# Altium schematic sheet Power Distribution - Front Lights.SchDoc
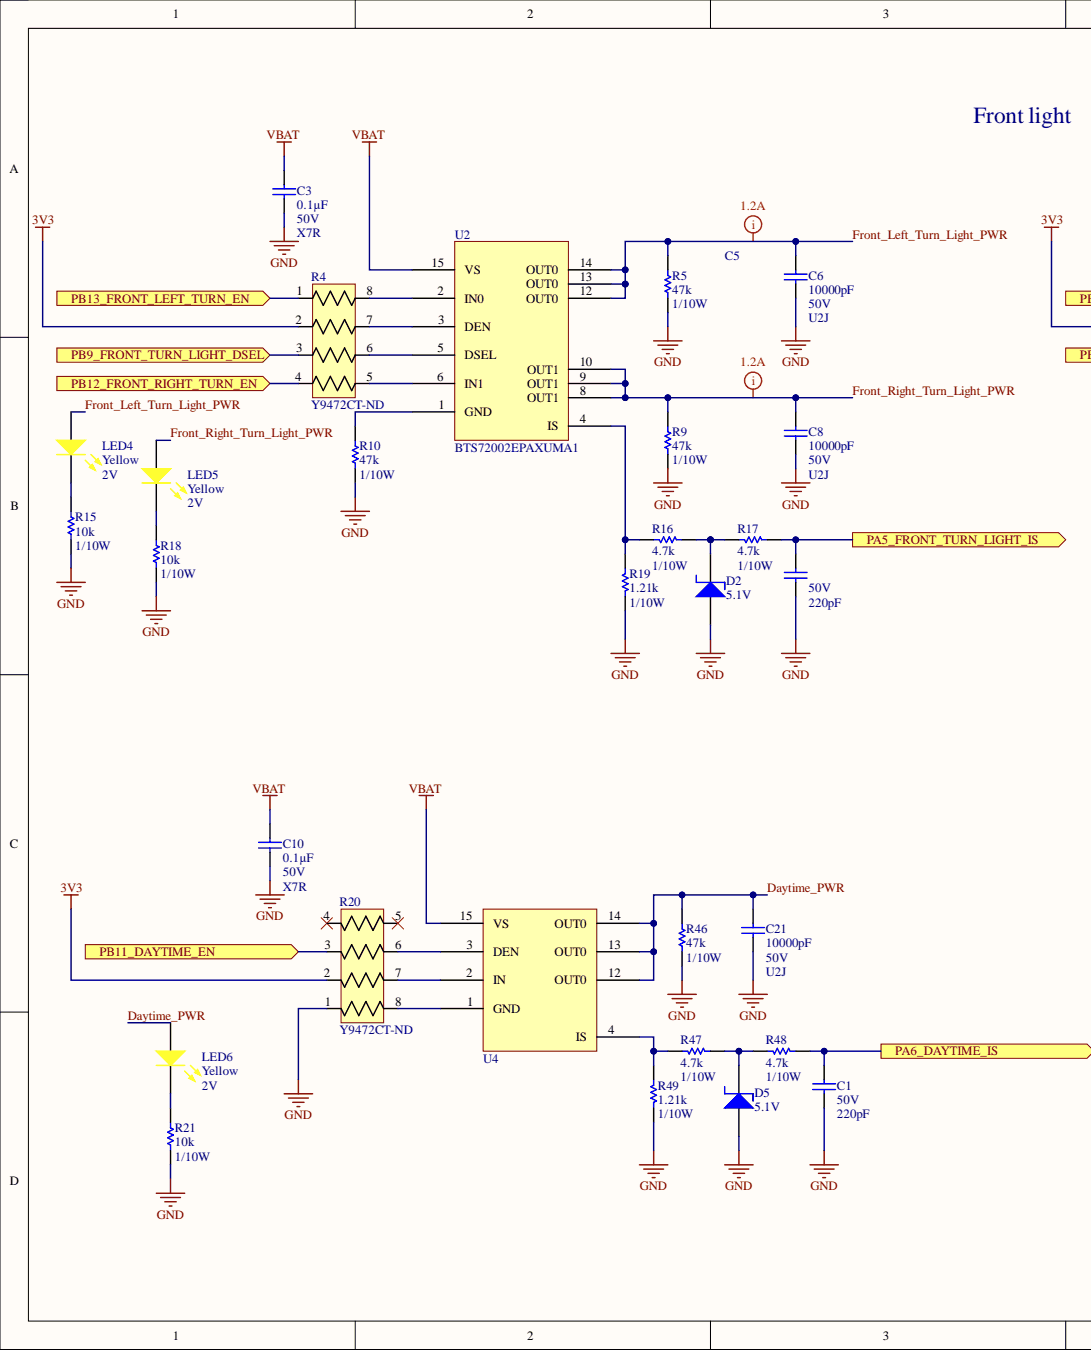
[diagram p0: Power Distribution - Front Lights.SchDoc, left]
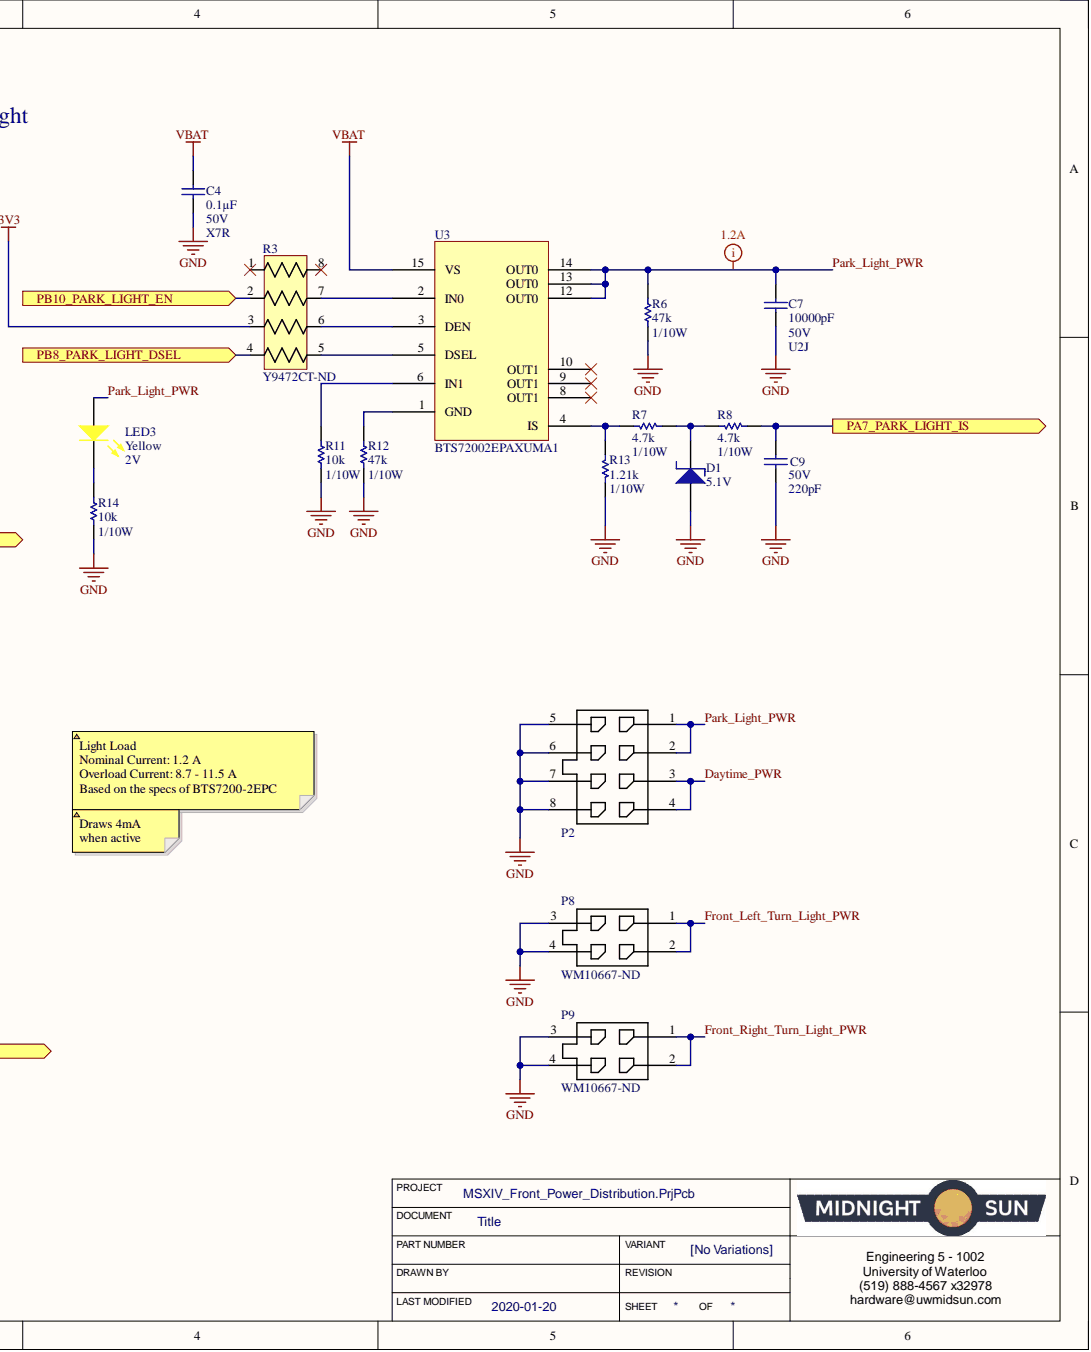
[diagram p1: Power Distribution - Front Lights.SchDoc, right]

## Parts
C1  Capacitance: 220pF  Voltage Rating: 50V  [CAP, 0603]  (hidden comment: CAP CER 220PF 50V C0G/NP0 0603)
C3  Capacitance: 0.1µF  Temperature Coefficient: X7R  Voltage: 50V  [CAP, 0603]  (hidden comment: CAP CER 0.1UF 50V 10% X7R 0603)
C4  Capacitance: 0.1µF  Temperature Coefficient: X7R  Voltage: 50V  [CAP, 0603]  (hidden comment: CAP CER 0.1UF 50V 10% X7R 0603)
C5  Capacitance: 220pF  Voltage Rating: 50V  [CAP, 0603]  (hidden comment: CAP CER 220PF 50V C0G/NP0 0603)
C6  Capacitance: 10000pF  Temperature Coefficient: U2J  Voltage: 50V  [CAP, 0603]  (hidden comment: CAP CER 10nF 50V 5% X7R 0603)
C7  Capacitance: 10000pF  Temperature Coefficient: U2J  Voltage: 50V  [CAP, 0603]  (hidden comment: CAP CER 10nF 50V 5% X7R 0603)
C8  Capacitance: 10000pF  Temperature Coefficient: U2J  Voltage: 50V  [CAP, 0603]  (hidden comment: CAP CER 10nF 50V 5% X7R 0603)
C9  Capacitance: 220pF  Voltage Rating: 50V  [CAP, 0603]  (hidden comment: CAP CER 220PF 50V C0G/NP0 0603)
C10  Capacitance: 0.1µF  Temperature Coefficient: X7R  Voltage: 50V  [CAP, 0603]  (hidden comment: CAP CER 0.1UF 50V 10% X7R 0603)
C21  Capacitance: 10000pF  Temperature Coefficient: U2J  Voltage: 50V  [CAP, 0603]  (hidden comment: CAP CER 10nF 50V 5% X7R 0603)
D1  Voltage - Zener (Nom) (Vz): 5.1V  [DO-214AA Diode]  (hidden comment: DIODE ZENER 5.1V 500MW SOD123)
D2  Voltage - Zener (Nom) (Vz): 5.1V  [DO-214AA Diode]  (hidden comment: DIODE ZENER 5.1V 500MW SOD123)
D5  Voltage - Zener (Nom) (Vz): 5.1V  [DO-214AA Diode]  (hidden comment: DIODE ZENER 5.1V 500MW SOD123)
LED3  Color: Yellow  Voltage - Forward (Vf) (Typ): 2V  [LED_0603]  (hidden comment: LED YELLOW CLEAR 2.1V 0603)
LED4  Color: Yellow  Voltage - Forward (Vf) (Typ): 2V  [LED_0603]  (hidden comment: LED YELLOW CLEAR 2.1V 0603)
LED5  Color: Yellow  Voltage - Forward (Vf) (Typ): 2V  [LED_0603]  (hidden comment: LED YELLOW CLEAR 2.1V 0603)
LED6  Color: Yellow  Voltage - Forward (Vf) (Typ): 2V  [LED_0603]  (hidden comment: LED YELLOW CLEAR 2.1V 0603)
P2  CONN 8POS MICRO-FIT 3mm  [CONN, 8POS MICRO-FIT]  (value not drawn)
P8  Supplier Part Number 1: WM10667-ND  [CONN 4POS MICROFIT (2x2)]  (hidden comment: CONN 4POS MICRO-FIT 3mm)
P9  Supplier Part Number 1: WM10667-ND  [CONN 4POS MICROFIT (2x2)]  (hidden comment: CONN 4POS MICRO-FIT 3mm)
R3  Supplier Part Number 1: Y9472CT-ND  [RES ARRAY 4RES 1206]  (hidden comment: RES ARRAY 4 RES 4.7K OHM 1206)
R4  Supplier Part Number 1: Y9472CT-ND  [RES ARRAY 4RES 1206]  (hidden comment: RES ARRAY 4 RES 4.7K OHM 1206)
R5  Power Rating: 1/10W  Resistance: 47k  [RES, 0603]  (hidden comment: RES 47K OHM 1% 1/10W 0603)
R6  Power Rating: 1/10W  Resistance: 47k  [RES, 0603]  (hidden comment: RES 47K OHM 1% 1/10W 0603)
R7  Resistance (Ohms): 4.7k  [RES, 0603]  (hidden comment: RES 4.7K OHM 1% 1/10W 0603)
R8  Resistance (Ohms): 4.7k  [RES, 0603]  (hidden comment: RES 4.7K OHM 1% 1/10W 0603)
R9  Power Rating: 1/10W  Resistance: 47k  [RES, 0603]  (hidden comment: RES 47K OHM 1% 1/10W 0603)
R10  Power Rating: 1/10W  Resistance: 47k  [RES, 0603]  (hidden comment: RES 47K OHM 1% 1/10W 0603)
R11  Power: 1/10W  Resistance: 10k  [RES, 0603]  (hidden comment: RES 10K OHM 1% 1/10W 0603)
R12  Power Rating: 1/10W  Resistance: 47k  [RES, 0603]  (hidden comment: RES 47K OHM 1% 1/10W 0603)
R13  Power: 1/10W  Resistance: 1.21k  [RES, 0603]  (hidden comment: RES 1.21K OHM 1% 1/10W 0603)
R14  Power: 1/10W  Resistance: 10k  [RES, 0603]  (hidden comment: RES 10K OHM 1% 1/10W 0603)
R15  Power: 1/10W  Resistance: 10k  [RES, 0603]  (hidden comment: RES 10K OHM 1% 1/10W 0603)
R16  Resistance (Ohms): 4.7k  [RES, 0603]  (hidden comment: RES 4.7K OHM 1% 1/10W 0603)
R17  Resistance (Ohms): 4.7k  [RES, 0603]  (hidden comment: RES 4.7K OHM 1% 1/10W 0603)
R18  Power: 1/10W  Resistance: 10k  [RES, 0603]  (hidden comment: RES 10K OHM 1% 1/10W 0603)
R19  Power: 1/10W  Resistance: 1.21k  [RES, 0603]  (hidden comment: RES 1.21K OHM 1% 1/10W 0603)
R20  Supplier Part Number 1: Y9472CT-ND  [RES ARRAY 4RES 1206]  (hidden comment: RES ARRAY 4 RES 4.7K OHM 1206)
R21  Power: 1/10W  Resistance: 10k  [RES, 0603]  (hidden comment: RES 10K OHM 1% 1/10W 0603)
R46  Power Rating: 1/10W  Resistance: 47k  [RES, 0603]  (hidden comment: RES 47K OHM 1% 1/10W 0603)
R47  Resistance (Ohms): 4.7k  [RES, 0603]  (hidden comment: RES 4.7K OHM 1% 1/10W 0603)
R48  Resistance (Ohms): 4.7k  [RES, 0603]  (hidden comment: RES 4.7K OHM 1% 1/10W 0603)
R49  Power: 1/10W  Resistance: 1.21k  [RES, 0603]  (hidden comment: RES 1.21K OHM 1% 1/10W 0603)
U2  Manufacturer Part Number: BTS72002EPAXUMA1  [IC LOAD SWITCH PG-TSDSO-14-22]  (hidden comment: IC LOAD SWITCH BTS7200-2EPA PG-TSDSO-14_1)
U3  Manufacturer Part Number: BTS72002EPAXUMA1  [IC LOAD SWITCH PG-TSDSO-14-22]  (hidden comment: IC LOAD SWITCH BTS7200-2EPA PG-TSDSO-14_1)
U4  IC LOAD SWITCH BTS70401EPA PG-TSDSO-14-22  [IC LOAD SWITCH PG-TSDSO-14-22]  (value not drawn)

## Ports
PA5_FRONT_TURN_LIGHT_IS, PA6_DAYTIME_IS, PA7_PARK_LIGHT_IS, PB8_PARK_LIGHT_DSEL, PB9_FRONT_TURN_LIGHT_DSEL, PB10_PARK_LIGHT_EN, PB11_DAYTIME_EN, PB12_FRONT_RIGHT_TURN_EN, PB13_FRONT_LEFT_TURN_EN

## Nets
3V3: R3.3 R4.2 R20.2
GND: C1.2 C3.2 C4.2 C5.2 C6.2 C7.2 C8.2 C9.2 C10.2 C21.2 D1.1 D2.1 D5.1 P2.5 P2.6 P2.7 P2.8 P8.3 P8.4 P9.3 P9.4 R5.2 R6.2 R9.2 R10.2 R11.2 R12.2 R13.2 R14.2 R15.2 R18.2 R19.2 R20.1 R21.2 R46.2 R49.2
VBAT: C3.1 C4.1 C10.1 U2.15(VS) U3.15(VS) U4.15(VS)
Daytime_PWR: C21.1 LED6.1 P2.3 P2.4 R46.1 U4.12(OUT0) U4.13(OUT0) U4.14(OUT0)
Front_Left_Turn_Light_PWR: C6.1 LED4.1 P8.1 P8.2 R5.1 U2.12(OUT0) U2.13(OUT0) U2.14(OUT0)
Front_Right_Turn_Light_PWR: C8.1 LED5.1 P9.1 P9.2 R9.1 U2.8(OUT1) U2.9(OUT1) U2.10(OUT1)
PA5_FRONT_TURN_LIGHT_IS: C5.1 R17.1 ; port PA5_FRONT_TURN_LIGHT_IS
PA6_DAYTIME_IS: C1.1 R48.1 ; port PA6_DAYTIME_IS
PA7_PARK_LIGHT_IS: C9.1 R8.1 ; port PA7_PARK_LIGHT_IS
Park_Light_PWR: C7.1 LED3.1 P2.1 P2.2 R6.1 U3.12(OUT0) U3.13(OUT0) U3.14(OUT0)
PB8_PARK_LIGHT_DSEL: R3.4 ; port PB8_PARK_LIGHT_DSEL
PB9_FRONT_TURN_LIGHT_DSEL: R4.3 ; port PB9_FRONT_TURN_LIGHT_DSEL
PB10_PARK_LIGHT_EN: R3.2 ; port PB10_PARK_LIGHT_EN
PB11_DAYTIME_EN: R20.3 ; port PB11_DAYTIME_EN
PB12_FRONT_RIGHT_TURN_EN: R4.4 ; port PB12_FRONT_RIGHT_TURN_EN
PB13_FRONT_LEFT_TURN_EN: R4.1 ; port PB13_FRONT_LEFT_TURN_EN
NetD1_2: D1.2 R7.1 R8.2
NetD2_2: D2.2 R16.1 R17.2
NetD5_2: D5.2 R47.1 R48.2
NetLED3_2: LED3.2 R14.1
NetLED4_2: LED4.2 R15.1
NetLED5_2: LED5.2 R18.1
NetLED6_2: LED6.2 R21.1
NetR3_5: R3.5 U3.5(DSEL)
NetR3_6: R3.6 U3.3(DEN)
NetR3_7: R3.7 U3.2(IN0)
NetR4_5: R4.5 U2.6(IN1)
NetR4_6: R4.6 U2.5(DSEL)
NetR4_7: R4.7 U2.3(DEN)
NetR4_8: R4.8 U2.2(IN0)
NetR7_2: R7.2 R13.1 U3.4(IS)
NetR10_1: R10.1 U2.1(GND)
NetR11_1: R11.1 U3.6(IN1)
NetR12_1: R12.1 U3.1(GND)
NetR16_2: R16.2 R19.1 U2.4(IS)
NetR20_6: R20.6 U4.3(DEN)
NetR20_7: R20.7 U4.2(IN)
NetR20_8: R20.8 U4.1(GND)
NetR47_2: R47.2 R49.1 U4.4(IS)

Not connected: R3 1 8; R20 4 5; U3 8(OUT1) 9(OUT1) 10(OUT1)

## Library data of the parts
C1 C5 C9 = CAP CER 220PF 50V C0G/NP0 0603: 220pF ±5% 50V Ceramic Capacitor C0G, NP0 0603 (1608 Metric); Manufacturer: KEMET; Manufacturer Part Number: C0603C221J5GACAUTO; Dissipation Factor: 0.1%; Case Code (Imperial): 0603; Case Code (Metric): 1608; Case/Package: 0603; Component Kind: Standard; ComponentLink1Description: https://datasheet.ciiva.com/18192/getdatasheetpartid-416741-18192869.pdf?src-supplier=Verical; ComponentLink1URL: https://datasheet.ciiva.com/18192/getdatasheetpartid-416741-18192869.pdf?src-supplier=Verical; ComponentLink2Description: https://datasheet.ciiva.com/22694/1633156-22694015.pdf?src-supplier=Element14; ComponentLink2URL: https://datasheet.ciiva.com/22694/1633156-22694015.pdf?src-supplier=Element14; Contact Plating: Tin; Depth: 0.8mm; Dielectric: C0G; Dielectric Material: Ceramic; Height: 0.8mm; Lead Free: Lead Free; Length: 1.6mm; Max Operating Temperature: 125°C; Min Operating Temperature: -55°C; Mount: Surface Mount; Package Quantity: 1; Packaging: Tape and Reel; Pricing 1: 1=0.14000, 10=0.09700, 100=0.04550, 500=0.03188, 1000=0.02640 (USD); Radiation Hardening: No; Ratings: AEC-Q200; REACH SVHC: No SVHC; RoHS: Yes; Stock 1: 35170; Supplier 1: Digi-Key; Supplier Part Number 1: 399-6868-1-ND; Termination: SMD/SMT; Thickness: 0.034inch; Tolerance: 5%; Voltage Rating (DC): 50V; Width: 0.031inch; Library Name: Schematic Diagrams.SchLib
C3 C4 C10 = CAP CER 0.1UF 50V 10% X7R 0603: 0.10µF ±10% 50V Ceramic Capacitor X7R 0603 (1608 Metric); Manufacturer 1: AVX Corporation; Manufacturer Part Number 1: 06035C104KAT2A; Component Kind: Standard; ComponentLink1Description: http://datasheets.avx.com/X7RDielectric.pdf; ComponentLink1URL: http://datasheets.avx.com/X7RDielectric.pdf; Height - Seated (Max): -; Lead Spacing: -; Lead Style: -; Mounting Type: Surface Mount, MLCC; Operating Temperature: -55°C ~ 125°C; Package / Case: 0603 (1608 Metric); Packaging: Cut Tape (CT); Part Status: Active; Ratings: -; Size / Dimension: 0.063" L x 0.032" W (1.60mm x 0.81mm); Supplier 1: Digi-Key; Supplier Part Number 1: 478-5052-1-ND; Thickness (Max): 0.035" (0.90mm); Tolerance: ±10%; Library Name: Schematic Diagrams.SchLib
C6-C8 C21 = CAP CER 10nF 50V 5% X7R 0603: 10000pF ±5% 50V Ceramic Capacitor U2J 0603 (1608 Metric); Manufacturer 1: KEMET; Manufacturer Part Number 1: C0603C103J5JAC7867; Component Kind: Standard; ComponentLink1Description: http://www.kemet.com/docfinder?Partnumber=C0603C103J5JAC7867; ComponentLink1URL: http://www.kemet.com/docfinder?Partnumber=C0603C103J5JAC7867; Failure Rate: -; Height - Seated (Max): -; Lead Spacing: -; Lead Style: -; Mounting Type: Surface Mount, MLCC; Operating Temperature: -55°C ~ 125°C; Package / Case: 0603 (1608 Metric); Packaging: Cut Tape (CT); Part Status: Active; Ratings: -; Size / Dimension: 0.063" L x 0.032" W (1.60mm x 0.80mm); Supplier 1: Digi-Key; Supplier Part Number 1: 399-13384-1-ND; Thickness (Max): 0.034" (0.87mm); Tolerance: ±5%; Library Name: Schematic Diagrams.SchLib
LED3-LED6 = LED YELLOW CLEAR 2.1V 0603: Yellow 590nm LED Indication - Discrete 2V 0603 (1608 Metric); Manufacturer: Wurth Electronics Inc.; Manufacturer Part Number: 150060YS75000; Current - Test: 20mA; Lens Color: Colorless; Lens Style/Size: Rectangle with Flat Top, 1.10mm x 0.70mm; Millicandela Rating: 120mcd; Viewing Angle: 140°; Wavelength - Peak: 595nm; Category: Optoelectronics; Component Kind: Standard; ComponentLink1Description: http://katalog.we-online.de/led/datasheet/150060YS75000.pdf; ComponentLink1URL: http://katalog.we-online.de/led/datasheet/150060YS75000.pdf; Features: -; Height (Max): 0.80mm; Lens Transparency: Clear; Mounting Type: Surface Mount; Package / Case: 0603 (1608 Metric); Packaging: Cut Tape (CT); Part Status: Active; Pricing 1: 1=0.21, 100=0.1617, 500=0.13964 (CAD); RoHS: RoHS Compliant; Size / Dimension: 1.60mm L x 0.80mm W; Stock 1: 10523; Supplier 1: Digi-Key; Supplier Device Package: 0603; Supplier Part Number 1: 732-4981-1-ND; Wavelength - Dominant: 590nm; Library Name: Schematic Diagrams.SchLib
P2 = CONN 8POS MICRO-FIT 3mm: Connector Header Through Hole 6 position 0.118" (3.00mm); Manufacturer: Molex, LLC; Manufacturer Part Number: 0430450827; Contact Length - Post: 0.125" (3.18mm); Material Flammability Rating: UL94 V-0; Number of Positions: 6; Number of Positions Loaded: All; Shrouding: Shrouded - 4 Wall; Style: Board to Cable/Wire; Applications: General Purpose, Medical, Military, Telecommunications; Category: Connectors, Interconnects; Component Kind: Standard; ComponentLink1Description: https://www.molex.com/pdm_docs/ps/PS-43045.pdf; ComponentLink1URL: https://www.molex.com/pdm_docs/ps/PS-43045.pdf; ComponentLink2Description: https://www.molex.com/webdocs/datasheets/pdf/en-us/0430450827_PCB_HEADERS.pdf; ComponentLink2URL: https://www.molex.com/webdocs/datasheets/pdf/en-us/0430450827_PCB_HEADERS.pdf; Connector Type: Header; Contact Finish - Mating: Tin; Contact Finish - Post: Tin; Contact Finish Thickness - Mating: 60.0µin (1.52µm); Contact Finish Thickness - Post: -; Contact Length - Mating: -; Contact Material: Brass; Contact Shape: Square; Contact Type: Male Pin; Current Rating: -; Fastening Type: Locking Ramp; Features: Board Guide; Ingress Protection: -; Insulation Color: Black; Insulation Height: 0.390" (9.91mm); Insulation Material: Liquid Crystal Polymer (LCP), Glass Filled; Mated Stacking Heights: -; Mounting Type: Through Hole; Number of Rows: 2; Operating Temperature: -; Overall Contact Length: -; Packaging: Tray; Part Status: Active; Pitch - Mating: 0.118" (3.00mm); Pricing 1: 1=2.69, 10=2.445, 25=2.2964, 50=2.1964, 100=2.0965, 250=1.8968, 500=1.74704, 1440=1.49746, 2880=1.39763 (CAD); RoHS: RoHS Compliant; Row Spacing - Mating: 0.118" (3.00mm); Stock 1: 5553; Supplier 1: Digi-Key; Supplier Part Number 1: WM10684-ND; Termination: Solder; Voltage Rating: -; Library Name: Schematic Diagrams.SchLib
P8 P9 = CONN 4POS MICRO-FIT 3mm: Micro-Fit 3.0 Vertical Header, 3.00mm Pitch, Dual Row, 4 Circuits, with PCB Polarizing Peg, Tin, Glow-Wire Capable, Black; Manufacturer: Molex, LLC; Manufacturer Part Number: 430450427; Contact Length - Post: 0.125" (3.18mm); Contact Resistance: 10mR; Material Flammability Rating: UL94 V-0; Number of Contacts: 4; Number of Ports: 1; Number of Positions: 6; Number of Positions Loaded: All; Pitch - Mating: 0.118" (3.00mm); Row Spacing: 0.118inch; Shrouding: Shrouded - 4 Wall; Style: Board to Cable/Wire; Applications: General Purpose, Medical, Military, Telecommunications; Category: Connectors, Interconnects; Color: Black; Component Kind: Standard; ComponentLink4Description: http://www.molex.com/webdocs/datasheets/pdf/en-us/0430450427_PCB_HEADERS.pdf; ComponentLink4URL: http://www.molex.com/webdocs/datasheets/pdf/en-us/0430450427_PCB_HEADERS.pdf; Connector Type: Header; Contact Finish - Mating: Tin; Contact Finish - Post: Tin; Contact Finish Thickness - Mating: 60.0µin (1.52µm); Contact Finish Thickness - Post: -; Contact Length - Mating: -; Contact Material: Brass; Contact Plating: Tin; Contact Shape: Square; Contact Type: Male Pin; Current Rating: -; Depth: 8.77mm; Fastening Type: Locking Ramp; Features: Board Guide; Housing Color: Black; Housing Material: Polymer; Ingress Protection: -; Insulation Color: Black; Insulation Height: 0.390" (9.91mm); Insulation Material: Liquid Crystal Polymer (LCP), Glass Filled; Length: 10.15mm; Mated Stacking Heights: -; Max Operating Temperature: 105°C; Min Operating Temperature: -40°C; Mount: Through Hole; Mounting Type: Through Hole; Number of Rows: 2; Number of Terminals: 4; Operating Temperature: -; Orientation: Straight; Overall Contact Length: -; Package Quantity: 2232; Packaging: Tray; Part Status: Active; Pitch: 3mm; RoHS: RoHS Compliant; Row Spacing - Mating: 0.118" (3.00mm); Supplier 1: Digi-Key; Termination: Solder; Voltage Rating: -; Library Name: Schematic Diagrams.SchLib
R3 R4 R20 = RES ARRAY 4 RES 4.7K OHM 1206: 4.7k Ohm ±5% 62.5mW Power Per Element Isolated 4 Resistor Network/Array ±200ppm/°C 1206 (3216 Metric), Convex, Long Side Terminals; Applications Automotive: AEC-Q200; Number of Resistors: 4; Applications: -; Category: Resistors; Circuit Type: Isolated; Component Kind: Standard; ComponentLink1Description: https://industrial.panasonic.com/cdbs/www-data/pdf/AOC0000/AOC0000C14.pdf; ComponentLink1URL: https://industrial.panasonic.com/cdbs/www-data/pdf/AOC0000/AOC0000C14.pdf; Height - Seated (Max): 0.024" (0.60mm); Mounting Type: Surface Mount; Number of Pins: 8; Operating Temperature: -55°C ~ 125°C; Package / Case: 1206 (3216 Metric), Convex, Long Side Terminals; Packaging: Cut Tape (CT); Part Status: Active; Power Per Element: 62.5mW; Resistance (Ohms): 4.7k; Resistor Matching Ratio: -; Resistor-Ratio-Drift: -; Series: EXB; Size / Dimension: 0.126" L x 0.063" W (3.20mm x 1.60mm); Supplier 1: Digi-Key; Supplier Device Package: 1206; Temperature Coefficient: ±200ppm/°C; Tolerance: ±5%; Library Name: Schematic Diagrams.SchLib
R5 R6 R9 R10 R12 R46 = RES 47K OHM 1% 1/10W 0603: 47 kOhms ±1% 0.1W, 1/10W Chip Resistor 0603 (1608 Metric) Automotive AEC-Q200 Thick Film; Manufacturer: Panasonic; Manufacturer Part Number: ERJ3EKF4702V; Max Power Dissipation: 100mW; Temperature Coefficient: 100ppm/°C; Voltage Rating: 75V; Working Voltage: 6V; Case Code (Imperial): 0603; Case Code (Metric): 1608; Case/Package: 0603; Component Kind: Standard; ComponentLink1Description: https://industrial.panasonic.com/cdbs/www-data/pdf/RDO0000/AOA0000C291.pdf; ComponentLink1URL: https://industrial.panasonic.com/cdbs/www-data/pdf/RDO0000/AOA0000C291.pdf; ComponentLink2Description: https://datasheet.ciiva.com/6138/aoa0000ce2-6138149.pdf?src-supplier=Farnell; ComponentLink2URL: https://datasheet.ciiva.com/6138/aoa0000ce2-6138149.pdf?src-supplier=Farnell; ComponentLink3Description: https://datasheet.ciiva.com/7802/aoa0000ce2-7802421.pdf?src-supplier=Element14; ComponentLink3URL: https://datasheet.ciiva.com/7802/aoa0000ce2-7802421.pdf?src-supplier=Element14; ComponentLink4Description: https://datasheet.ciiva.com/48531/aoa0000ce2-48531029.pdf?src-supplier=Avnet; ComponentLink4URL: https://datasheet.ciiva.com/48531/aoa0000ce2-48531029.pdf?src-supplier=Avnet; ComponentLink5Description: https://datasheet.ciiva.com/16514/aoa0000ce2-16514071.pdf?src-supplier=Avnet+Asia; ComponentLink5URL: https://datasheet.ciiva.com/16514/aoa0000ce2-16514071.pdf?src-supplier=Avnet+Asia; ComponentLink6Description: https://datasheet.ciiva.com/46398/1678782-46398088.pdf?src-supplier=Element14; ComponentLink6URL: https://datasheet.ciiva.com/46398/1678782-46398088.pdf?src-supplier=Element14; ComponentLink7Description: https://datasheet.ciiva.com/24069/aoa0000ce2-24069209.pdf?src-supplier=Mouser; ComponentLink7URL: https://datasheet.ciiva.com/24069/aoa0000ce2-24069209.pdf?src-supplier=Mouser; ComponentLink8Description: https://datasheet.ciiva.com/46398/1678782-46398089.pdf?src-supplier=Element14; ComponentLink8URL: https://datasheet.ciiva.com/46398/1678782-46398089.pdf?src-supplier=Element14; ComponentLink9Description: https://datasheet.ciiva.com/30236/aoa0000ce2-30236698.pdf?src-supplier=Onlinecomponents.com; ComponentLink9URL: https://datasheet.ciiva.com/30236/aoa0000ce2-30236698.pdf?src-supplier=Onlinecomponents.com; ComponentLink10Description: https://datasheet.ciiva.com/22722/426256-22722781.pdf?src-supplier=Element14; ComponentLink10URL: https://datasheet.ciiva.com/22722/426256-22722781.pdf?src-supplier=Element14; ComponentLink11Description: https://datasheet.ciiva.com/16758/70260748-16758831.pdf?src-supplier=Allied+Electronics; ComponentLink11URL: https://datasheet.ciiva.com/16758/70260748-16758831.pdf?src-supplier=Allied+Electronics; Composition: Thick Film; Contact Plating: Tin; Depth: 0.8mm; Features: Automotive AEC-Q200; Height: 0.022inch; Lead Free: Lead Free; Length: 1.6mm; Max Operating Temperature: 155°C; Military Standard: Not; Min Operating Temperature: -55°C; Mount: Surface Mount; Number of Pins: 2; Number of Terminations: 2; Package Quantity: 1; Packaging: Tape and Reel; Pricing 1: 1 = 0.100000, 10 = 0.065000, 100 = 0.026400, 1000 = 0.011860, 2500 = 0.010290 (USD); Radiation Hardening: No; REACH SVHC: No SVHC; RoHS: Yes; Stock 1: 1704740; Supplier 1: Digi-Key; Supplier Part Number 1: P47.0KHCT-ND; Termination: SMD/SMT; Tolerance: 1%; Voltage Rating (DC): 75V; Width: 0.031inch; Library Name: Schematic Diagrams.SchLib
R7 R8 R16 R17 R47 R48 = RES 4.7K OHM 1% 1/10W 0603: 4.7k Ohm ±1% 0.1W, 1/10W Chip Resistor 0603 (1608 Metric) Moisture Resistant Thick Film; Manufacturer 1: Yageo; Manufacturer Part Number 1: RC0603FR-074K7L; Description 1: RES SMD 4.7K OHM 1% 1/10W 0603; Temperature Coefficient: ±100ppm/°C; Category: Resistors; Component Kind: Standard; ComponentLink1Description: http://www.yageo.com/documents/recent/PYu-RC_Group_51_RoHS_L_7.pdf; ComponentLink1URL: http://www.yageo.com/documents/recent/PYu-RC_Group_51_RoHS_L_7.pdf; Composition: Thick Film; Failure Rate: -; Features: Moisture Resistant; Height - Seated (Max): 0.022" (0.55mm); Operating Temperature: -55°C ~ 155°C; Package / Case: 0603 (1608 Metric); Packaging: Cut Tape (CT); Part Status: Active; Power (Watts): 1/10W; Pricing 1: 1=0.16, 10=0.022, 100=0.0089, 1000=0.00402, 2500=0.00349 (CAD); RoHS: RoHS Compliant; Size / Dimension: 0.063" L x 0.031" W (1.60mm x 0.80mm); Stock 1: 3487566; Supplier 1: Digi-Key; Supplier Device Package: 0603; Supplier Part Number 1: 311-4.70KHRCT-ND; Tolerance: ±1%; Library Name: Schematic Diagrams.SchLib
R11 R14 R15 R18 R21 = RES 10K OHM 1% 1/10W 0603: RES SMD 10K OHM 1% 1/10W 0603; Manufacturer 1: Yageo; Manufacturer Part Number 1: RC0603FR-0710KL; Temperature Coefficient: ±100ppm/°C; Component Kind: Standard; ComponentLink1Description: http://www.yageo.com/documents/recent/PYu-RC_Group_51_RoHS_L_7.pdf; ComponentLink1URL: http://www.yageo.com/documents/recent/PYu-RC_Group_51_RoHS_L_7.pdf; Composition: Thick Film; Failure Rate: -; Height - Seated (Max): 0.022" (0.55mm); Operating Temperature: -55°C ~ 155°C; Package / Case: 0603 (1608 Metric); Packaging: Cut Tape (CT); Part Status: Active; Size / Dimension: 0.063" L x 0.031" W (1.60mm x 0.80mm); Supplier 1: Digi-Key; Supplier Device Package: 0603; Supplier Part Number 1: 311-10.0KHRCT-ND; Tolerance: ±1%; Library Name: Schematic Diagrams.SchLib
R13 R19 R49 = RES 1.21K OHM 1% 1/10W 0603: 1.21k Ohm ±1% 0.1W, 1/10W Chip Resistor 0603 (1608 Metric) Moisture Resistant Thick Film; Manufacturer 1: Yageo; Manufacturer Part Number 1: RC0603FR-071K21L; Temperature Coefficient: ±100ppm/°C; Component Kind: Standard; ComponentLink1Description: http://www.yageo.com/documents/recent/PYu-RC_Group_51_RoHS_L_7.pdf; ComponentLink1URL: http://www.yageo.com/documents/recent/PYu-RC_Group_51_RoHS_L_7.pdf; Composition: Thick Film; Failure Rate: -; Height - Seated (Max): 0.022" (0.55mm); Operating Temperature: -55°C ~ 155°C; Package / Case: 0603 (1608 Metric); Packaging: Cut Tape (CT); Part Status: Active; Size / Dimension: 0.063" L x 0.031" W (1.60mm x 0.80mm); Supplier 1: Digi-Key; Supplier Device Package: 0603; Supplier Part Number 1: 311-1.21KHRCT-ND; Tolerance: ±1%; Library Name: Schematic Diagrams.SchLib
U2 U3 = IC LOAD SWITCH BTS7200-2EPA PG-TSDSO-14_1: Power Switch/Driver 1:1 N-Channel 1.2A PG-TSDSO-14-22; Manufacturer: Infineon; Component Kind: Standard; ComponentLink1Description: https://www.infineon.com/dgdl/Infineon-BTS7200-2EPA-DS-v01_00-EN.pdf?fileId=5546d462636cc8fb016421e548a61215; ComponentLink1URL: https://www.infineon.com/dgdl/Infineon-BTS7200-2EPA-DS-v01_00-EN.pdf?fileId=5546d462636cc8fb016421e548a61215; Pricing 1: 1=1.31000, 10=1.17500, 100=0.91640, 500=0.75700, 1000=0.59763 (USD); RoHS: No; Stock 1: 1973; Supplier 1: Digi-Key; Supplier Part Number 1: BTS72002EPAXUMA1CT-ND; Library Name: Schematic Diagrams.SchLib
U4 = IC LOAD SWITCH BTS70401EPA PG-TSDSO-14-22: Power Switch/Driver 1:1 N-Channel 4.5A PG-TSDSO-14-22; Manufacturer: Infineon; Manufacturer Part Number: BTS70401EPAXUMA1; Component Kind: Standard; ComponentLink1Description: https://www.infineon.com/dgdl/Infineon-BTS7040-1EPA-DS-v01_00-EN.pdf?fileId=5546d462636cc8fb0163fe8f965308bc; ComponentLink1URL: https://www.infineon.com/dgdl/Infineon-BTS7040-1EPA-DS-v01_00-EN.pdf?fileId=5546d462636cc8fb0163fe8f965308bc; Supplier 1: Digi-Key; Supplier Part Number 1: BTS70401EPAXUMA1CT-ND; Library Name: Schematic Diagrams.SchLib
D1 D2 D5 = DIODE ZENER 5.1V 500MW SOD123: Component Kind: Standard; Supplier 1: Digi-Key; Supplier Part Number 1: MMSZ5231BT1GOSCT-ND; Library Name: Schematic Diagrams.SchLib
